# Altium schematic sheet Z26 - TPS84A20 DC-DC Converter.SchDoc
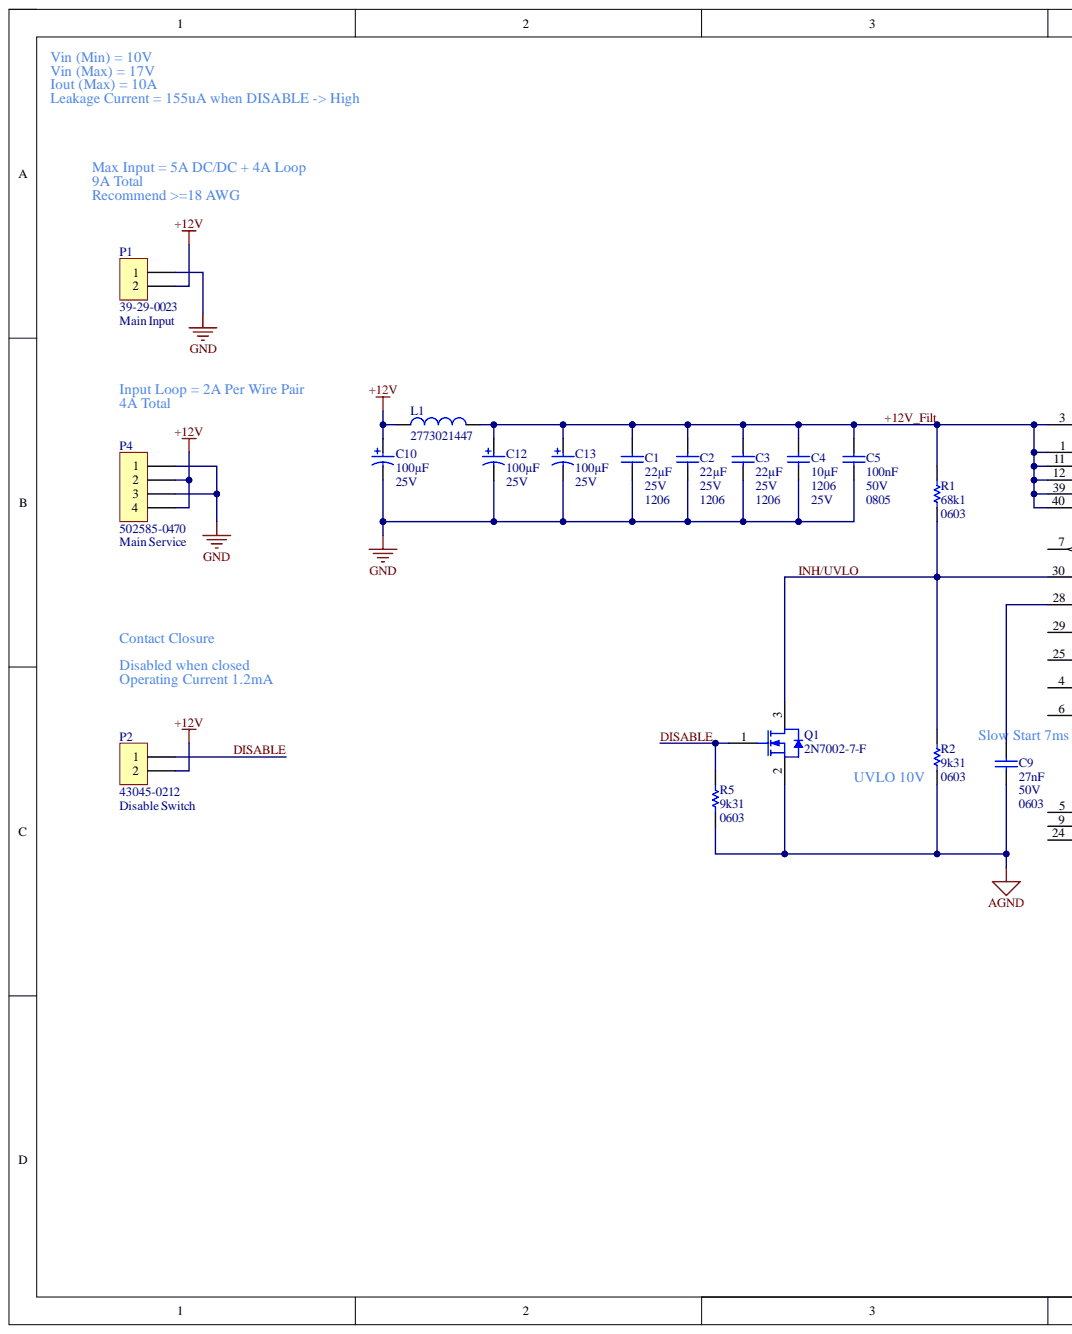
[diagram p0: Z26 - TPS84A20 DC-DC Converter.SchDoc, left]
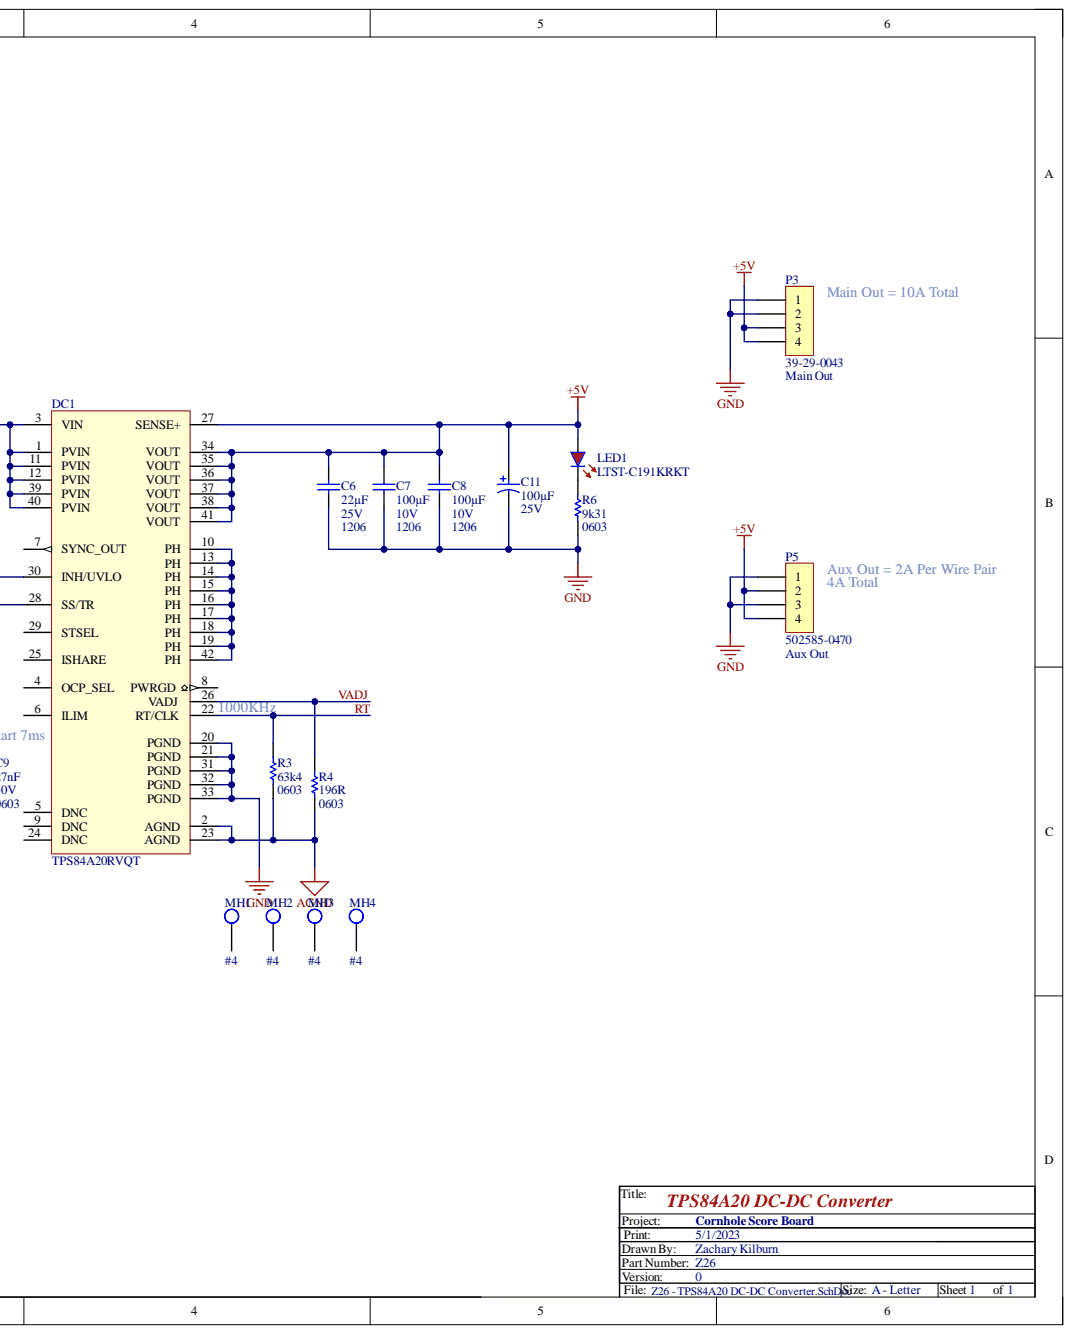
[diagram p1: Z26 - TPS84A20 DC-DC Converter.SchDoc, right]

## Parts
C1  value 22µF  PackageName: 1206  Voltage: 25V  [PCC-XXX-CAP1206(3216)-190-N]
C2  value 22µF  PackageName: 1206  Voltage: 25V  [PCC-XXX-CAP1206(3216)-190-N]
C3  value 22µF  PackageName: 1206  Voltage: 25V  [PCC-XXX-CAP1206(3216)-190-N]
C4  value 10µF  PackageName: 1206  Voltage: 25V  [PCC-XXX-CAP1206(3216)-100-N]
C5  value 100nF  PackageName: 0805  Voltage: 50V  [PCC-XXX-CAP0805(2012)-100-N]
C6  value 22µF  PackageName: 1206  Voltage: 25V  [PCC-XXX-CAP1206(3216)-190-N]
C7  value 100µF  PackageName: 1206  Voltage: 10V  [PCC-XXX-CAP1206(3216)-100-N]
C8  value 100µF  PackageName: 1206  Voltage: 10V  [PCC-XXX-CAP1206(3216)-100-N]
C9  value 27nF  Voltage: 50V  [PCC-XXX-CAP0603(1608)-100-N]
C10  value 100µF  Voltage: 25V  [PCC-PANA-CAP-ALUSMD-E-D8.0-H6.5-R]
C11  value 100µF  Voltage: 25V  [PCC-PANA-CAP-ALUSMD-E-D8.0-H6.5-R]
C12  value 100µF  Voltage: 25V  [PCC-PANA-CAP-ALUSMD-E-D8.0-H6.5-R]
C13  value 100µF  Voltage: 25V  [PCC-PANA-CAP-ALUSMD-E-D8.0-H6.5-R]
DC1  PartNumber: TPS84A20RVQT  [PCC-TI-B3QFN-RVQ-42L-R]
L1  PartNumber: 2773021447  [PCC-FAIR-73SM-BEAD-2L-R]
LED1  LTST-C191KRKT  [PCC-LITE-LTST-C191-R]
MH1  #4  [PCC-XXX-MH-#4-ROUND-PLATED-H128P230M290]  (no BOM)
MH2  #4  [PCC-XXX-MH-#4-ROUND-PLATED-H128P230M290]  (no BOM)
MH3  #4  [PCC-XXX-MH-#4-ROUND-PLATED-H128P230M290]  (no BOM)
MH4  #4  [PCC-XXX-MH-#4-ROUND-PLATED-H128P230M290]  (no BOM)
P1  39-29-0023  Name: Main Input  [PCC-MOLX-5566-VERT-02L-2X1-R]
P2  43045-0212  Name: Disable Switch  [PCC-MOLX-43045-0212-R]
P3  39-29-0043  Name: Main Out  [PCC-MOLX-5566-VERT-04L-2X2-R]
P4  502585-0470  Name: Main Service  [PCC-MOLX-502585-0470-R]
P5  502585-0470  Name: Aux Out  [PCC-MOLX-502585-0470-R]
Q1  PartNumber: 2N7002-7-F  [PCC-DIOD-SOT23-R]
R1  PackageName: 0603  ValueText: 68k1  [PCC-XXX-RES0603(1608)-N]  (hidden comment: 68K1 1% 0603(1608))
R2  PackageName: 0603  ValueText: 9k31  [PCC-XXX-RES0603(1608)-N]  (hidden comment: 9K31 1% 0603(1608))
R3  PackageName: 0603  ValueText: 63k4  [PCC-XXX-RES0603(1608)-N]  (hidden comment: 63K4 1% 0603(1608))
R4  PackageName: 0603  ValueText: 196R  [PCC-XXX-RES0603(1608)-N]  (hidden comment: 196R 1% 0603(1608))
R5  PackageName: 0603  ValueText: 9k31  [PCC-XXX-RES0603(1608)-N]  (hidden comment: 9K31 1% 0603(1608))
R6  PackageName: 0603  ValueText: 9k31  [PCC-XXX-RES0603(1608)-N]  (hidden comment: 9K31 1% 0603(1608))

## Nets
+5V: C6.2 C7.2 C8.2 C11.1 DC1.27(SENSE+) DC1.34(VOUT) DC1.35(VOUT) DC1.36(VOUT) DC1.37(VOUT) DC1.38(VOUT) DC1.41(VOUT) LED1.1 P3.3 P3.4 P5.2 P5.4
+12V: C10.1 L1.1 P1.2 P2.2 P4.2 P4.4
AGND: C9.1 DC1.2(AGND) DC1.23(AGND) Q1.2 R2.1 R3.1 R4.1 R5.2
GND: C1.1 C2.1 C3.1 C4.1 C5.1 C6.1 C7.1 C8.1 C10.2 C11.2 C12.2 C13.2 DC1.20(PGND) DC1.21(PGND) DC1.31(PGND) DC1.32(PGND) DC1.33(PGND) P1.1 P3.1 P3.2 P4.1 P4.3 P5.1 P5.3 R6.2
+12V_Filt: C1.2 C2.2 C3.2 C4.2 C5.2 C12.1 C13.1 DC1.1(PVIN) DC1.3(VIN) DC1.11(PVIN) DC1.12(PVIN) DC1.39(PVIN) DC1.40(PVIN) L1.2 R1.2
DISABLE: P2.1 Q1.1 R5.1
INH/UVLO: DC1.30(INH/UVLO) Q1.3 R1.1 R2.2
RT: DC1.22(RT/CLK) R3.2
VADJ: DC1.26(VADJ) R4.2
NetC9_2: C9.2 DC1.28(SS/TR)
NetDC1_10: DC1.10(PH) DC1.13(PH) DC1.14(PH) DC1.15(PH) DC1.16(PH) DC1.17(PH) DC1.18(PH) DC1.19(PH) DC1.42(PH)
NetLED1_2: LED1.2 R6.1

Not connected: DC1 4(OCP_SEL) 5(DNC) 6(ILIM) 7(SYNC_OUT) 8(PWRGD) 9(DNC) 24(DNC) 25(ISHARE) 29(STSEL); MH1 1; MH2 1; MH3 1; MH4 1

## Library data of the parts
C1-C3 C6 = CMP-014301-1: CAP 22uF 25V ±10% 1206 (3216 Metric); Component Kind: Standard; Library Name: Generic Capacitors.IntLib; MountingTechnology: Surface Mount; Tolerence: 10%
C4 = CMP-014349-0: CAP 10uF 25V ±10% 1206 (3216 Metric); Tolerence: Â±10%; Component Kind: Standard; Library Name: Generic Capacitors.IntLib; MountingTechnology: Surface Mount
C5 = CMP-013765-0: CAP 100nF 50V ±10% 0805 (2012 Metric) SMD; Tolerence: Â±10%; Component Kind: Standard; Library Name: Generic Capacitors.IntLib; MountingTechnology: Surface Mount
C7 C8 = CMP-014450-0: CAP 100uF 10V ±20% 1206 (3216 Metric)  SMD; Tolerence: Â±20%; Component Kind: Standard; Library Name: Generic Capacitors.IntLib; MountingTechnology: Surface Mount
C9 = CMP-012363-1: CAP 27nF 50V ±10% 0603 (1608 Metric) SMD; Tolerence: Â±10%; Component Kind: Standard; Library Name: Generic Capacitors.IntLib; MountingTechnology: Surface Mount; PackageName: 0603
C10-C13 = CMP-015090-0: Capacitor, Aluminum Electrolytic, 100uF, 25V, SMD, Size E; Manufacturer: Panasonic; PartNumber: EEE-TG1E101UP; Ripple Current: 100mA; Component Kind: Standard; ComponentLink1Description: Manufacturer URL; ComponentLink1URL: https://industrial.panasonic.com/; ComponentLink2Description: Product URL; ComponentLink2URL: https://industrial.panasonic.com/ww/products/pt/aluminum-cap-smd/models/EEETG1E101UP; ComponentLink3Description: Datasheet; ComponentLink3URL: https://industrial.panasonic.com/cdbs/www-data/pdf/RDE0000/ABA0000C1187.pdf; ComponentLink4Description: Package Specification; ComponentLink4URL: https://industrial.panasonic.com/cdbs/www-data/pdf/RDE0000/ABA0000C1187.pdf; HelpURL: https://industrial.panasonic.com/ww/products/pt/aluminum-cap-smd/models/EEETG1E101UP; Library Name: Aluminum Electrolytic Capacitors.IntLib; MountingTechnology: Surface Mount; OperatingTemperature: -40C to +125C; PackageName: E
DC1 = CMP-007475-0: Buck Switching Regulator, Integrated Inductor, 2.95-17Vin, 0.6-5.5Vout, 10A, SMT; Manufacturer: Texas Instruments; InputVoltageMax: 17V; InputVoltageMin: 2.95V; OutputVoltageMax: 5.5V; OutputVoltageMin: 600mV; Component Kind: Standard; ComponentLink1Description: Manufacturer URL; ComponentLink1URL: https://www.ti.com/; ComponentLink2Description: Product URL; ComponentLink2URL: https://www.ti.com/product/TPS84A20#design-development##reference-designs; ComponentLink3Description: Datasheet; ComponentLink3URL: https://www.ti.com/lit/ds/symlink/tps84a20.pdf?ts=1614033397645&ref_url=https%253A%252F%252Fwww.ti.com%252Fproduct%252FTPS84A20; ComponentLink4Description: Package Specification; ComponentLink4URL: https://www.ti.com/lit/ml/mpqf306b/mpqf306b.pdf?ts=1614033779038&ref_url=https%253A%252F%252Fwww.ti.com%252Fproduct%252FTPS84A20; Current: 10A; HelpURL: https://www.ti.com/product/TPS84A20#design-development##reference-designs; Library Name: Integrated_Circuits.IntLib; MountingTechnology: Surface Mount; OperatingTemperature: -40C to +85C; PackageName: BQFN42; RoHS: Yes; Type: Switching
L1 = CMP-007476-0: Ferrite Bead, 100 Ohm @ 25MHz, 5A; Manufacturer: Fair-Rite; Impedance@100MHz: 100; Component Kind: Standard; ComponentLink1Description: Manufacturer URL; ComponentLink1URL: https://www.fair-rite.com/; ComponentLink2Description: Product URL; ComponentLink2URL: https://www.fair-rite.com/product/sm-beads-differential-mode-2773021447/; ComponentLink3Description: Datasheet; ComponentLink3URL: http://www.fair-rite.com/wp-content/themes/fair-rite/print_product.php?pid=18872; ComponentLink4Description: Package Specification; ComponentLink4URL: http://www.fair-rite.com/wp-content/themes/fair-rite/print_product.php?pid=18872; Current: 5A; HelpURL: https://www.fair-rite.com/product/sm-beads-differential-mode-2773021447/; MountingTechnology: Surface Mount; OperatingTemperature: -55C to +125C; PackageName: 73SM Bead; RoHS: Yes; Library Name: Ferrites.IntLib
LED1 = CMP-000133-1: SMD LED 0603, Red 631nm; Manufacturer: Lite-On; Current: 0.03A; Peak Current: 0.08A; Voltage: 2.0VDC; Color: Red; Component Kind: Standard; ComponentLink1Description: Manufacturer URL; ComponentLink1URL: http://optoelectronics.liteon.com/; ComponentLink2Description: Product URL; ComponentLink2URL: http://optoelectronics.liteon.com/en-global/Led/LED-Component/Detail/448/; ComponentLink3Description: Datasheet; ComponentLink3URL: http://optoelectronics.liteon.com/upload/download/DS22-2000-223/S_110_LTST-C191KRKT.pdf; ComponentLink4Description: Package Specification; ComponentLink4URL: http://optoelectronics.liteon.com/upload/download/DS22-2000-223/S_110_LTST-C191KRKT.pdf; HelpURL: http://optoelectronics.liteon.com/upload/download/DS22-2000-223/S_110_LTST-C191KRKT.pdf; Library Name: Optoelectronics.IntLib; MountingTechnology: Surface Mount; OperatingTemperature: -55C to +85C; PackageName: 0603 (1608 Metric); PartNumber: LTST-C191KRKT; RoHS: Yes; StorageTemperature: -55C to +85C; Wavelength: 631nm
MH1-MH4 = CMP-000163-2: #4 Mounting Hole, Round, Plated, No Vias; Units: Imperial; Library Name: MountingHoleImperial.SchLib; Size: #4
P1 = CMP-000899-0: Molex Mini-Fit Jr. Connector, Dual-Row, 2-Position, Vertical, Gold Plating; Current: 9A; MatingStyle: Plug; Pitch: 4.2mm; Positions: 2; Voltage: 600V; Component Kind: Standard; ComponentLink1Description: Manufacturer URL; ComponentLink1URL: https://www.molex.com; ComponentLink2Description: Product URL; ComponentLink2URL: https://www.molex.com/molex/products/datasheet.jsp?part=active/0039290023_PCB_HEADERS.xml; ComponentLink3Description: Datasheet; ComponentLink3URL: https://www.molex.com/webdocs/datasheets/pdf/en-us/0039290023_PCB_HEADERS.pdf; ComponentLink4Description: Package Specification; ComponentLink4URL: https://www.molex.com/pdm_docs/sd/039290023_sd.pdf; HelpURL: https://www.molex.com/pdm_docs/sd/039290023_sd.pdf; Library Name: Connectors.SchLib; Manufacturer: Molex; MountingTechnology: Through Hole; OperatingTemperature: Vertical; Orientation: -40C to +105C; PackageName: Mini-Fit Jr.; PartNumber: 39-29-0023; RoHS: Yes; Rows: 2; StorageTemperature: -40C to +105C
P2 = CMP-000026-0: Molex Micro-Fit 3.0 Connector, Dual-Row, 2-Position, Vertical, 3mm Pitch; Current: 5A; MatingStyle: Plug; Positions: 2; Voltage: 250V; Component Kind: Standard; ComponentLink1Description: Manufacturer URL; ComponentLink1URL: http://www.molex.com; ComponentLink2Description: Product URL; ComponentLink2URL: http://www.molex.com/molex/products/datasheet.jsp?part=active/0430450212_PCB_HEADERS.xml; ComponentLink3Description: Datasheet; ComponentLink3URL: http://www.molex.com/pdm_docs/ps/PS-43045.pdf; ComponentLink4Description: Package Specification; ComponentLink4URL: http://www.molex.com/pdm_docs/sd/430450212_sd.pdf; HelpURL: http://www.molex.com/pdm_docs/sd/430450212_sd.pdf; Library Name: Connectors.SchLib; Manufacturer: Molex; MountingTechnology: Through Hole; OperatingTemperature: -40C to +105C; Orientation: Vertical; PackageName: Micro-Fit 3.0; PartNumber: 43045-0212; Pitch: 3mm; RoHS: Yes; Rows: 2; StorageTemperature: -40C to +105C
P3 = CMP-000900-0: Molex Mini-Fit Jr. Connector, Dual-Row, 4-Position, Vertical, Gold Plating; Current: 9A; MatingStyle: Plug; Pitch: 4.2mm; Positions: 4; Rows: 2; Voltage: 600V; Component Kind: Standard; ComponentLink1Description: Manufacturer URL; ComponentLink1URL: https://www.molex.com; ComponentLink2Description: Product URL; ComponentLink2URL: https://www.molex.com/molex/products/datasheet.jsp?part=active/0039290043_PCB_HEADERS.xml; ComponentLink3Description: Datasheet; ComponentLink3URL: https://www.molex.com/webdocs/datasheets/pdf/en-us/0039290043_PCB_HEADERS.pdf; ComponentLink4Description: Package Specification; ComponentLink4URL: https://www.molex.com/pdm_docs/sd/039290023_sd.pdf; HelpURL: https://www.molex.com/pdm_docs/sd/039290023_sd.pdf; Library Name: Connectors.SchLib; Manufacturer: Molex; MountingTechnology: Through Hole; OperatingTemperature: Vertical; Orientation: -40C to +105C; PackageName: Mini-Fit Jr.; PartNumber: 39-29-0043; RoHS: Yes; StorageTemperature: -40C to +105C
P4 P5 = CMP-000002-0: Molex CLIK-Mate Series Connector, Single-Row, 4-Position, Right-Angle, 1.5mm Pitch; Current: 2A; MatingStyle: Plug; PackingType: Reel; Positions: 4; Rows: 1; Voltage: 100V; Component Kind: Standard; ComponentLink1Description: Manufacturer URL; ComponentLink1URL: http://www.molex.com; ComponentLink2Description: Product URL; ComponentLink2URL: http://www.molex.com/molex/products/datasheet.jsp?part=active/5025850470_PCB_RECEPTACLES.xml; ComponentLink3Description: Datasheet; ComponentLink3URL: http://www.molex.com/pdm_docs/ps/PS-502584-001.pdf; ComponentLink4Description: Package Specification; ComponentLink4URL: http://www.molex.com/pdm_docs/sd/5025850470_sd.pdf; HelpURL: http://www.molex.com/pdm_docs/sd/5025850470_sd.pdf; Library Name: Connectors.SchLib; Manufacturer: Molex; MountingTechnology: Surface Mount; OperatingTemperature: -40C to +105C; Orientation: Right Angle; PackageName: CLIK-Mate; PartNumber: 502585-0470; Pitch: 1.5mm; RoHS: Yes; StorageTemperature: +5C to +35C
Q1 = CMP-007342-0: MOSFET, N-Channel, 60V, 115mA, SOT23; Manufacturer: Diodes Incorporated; Peak Current: 800mA; Vgs(th): 2.5V; Component Kind: Standard; ComponentLink1Description: Manufacturer URL; ComponentLink1URL: https://www.diodes.com/; ComponentLink2Description: Product URL; ComponentLink2URL: https://www.diodes.com/part/view/2N7002; ComponentLink3Description: Datasheet; ComponentLink3URL: https://www.diodes.com/assets/Datasheets/ds11303.pdf; ComponentLink4Description: Package Specification; ComponentLink4URL: https://www.diodes.com/assets/Package-Files/SOT23.pdf; Current: 115mA; Doping: N-Channel; HelpURL: https://www.diodes.com/part/view/2N7002; Library Name: Transistors.IntLib; MountingTechnology: Surface Mount; OperatingTemperature: -55C to +150C; PackageName: SOT23-3; RoHS: Yes; Voltage: 60V
R1 = CMP-001982-1: 68K1 0.1W 1% 0603 (1608 Metric)  SMD; Power: 100mW; Value: 68.1k; Component Kind: Standard; MountingTechnology: Surface Mount; Tolerence: 1%; Type: Standard; Library Name: IEC Primary Vault (Blazing)
R2 R5 R6 = CMP-002354-1: 9K31 0.1W 1% 0603 (1608 Metric)  SMD; Power: 100mW; Value: 9.31k; Component Kind: Standard; MountingTechnology: Surface Mount; Tolerence: 1%; Type: Standard; Library Name: IEC Primary Vault (Blazing)
R3 = CMP-002075-1: 63K4 0.1W 1% 0603 (1608 Metric)  SMD; Power: 100mW; Value: 63.4k; Component Kind: Standard; MountingTechnology: Surface Mount; Tolerence: 1%; Type: Standard; Library Name: IEC Primary Vault (Blazing)
R4 = CMP-002687-1: 196R 0.1W 1% 0603 (1608 Metric)  SMD; Power: 100mW; Value: 196; Component Kind: Standard; MountingTechnology: Surface Mount; Tolerence: 1%; Type: Standard; Library Name: IEC Primary Vault (Blazing)
